# Altium schematic sheet weight V4.0.SchDoc
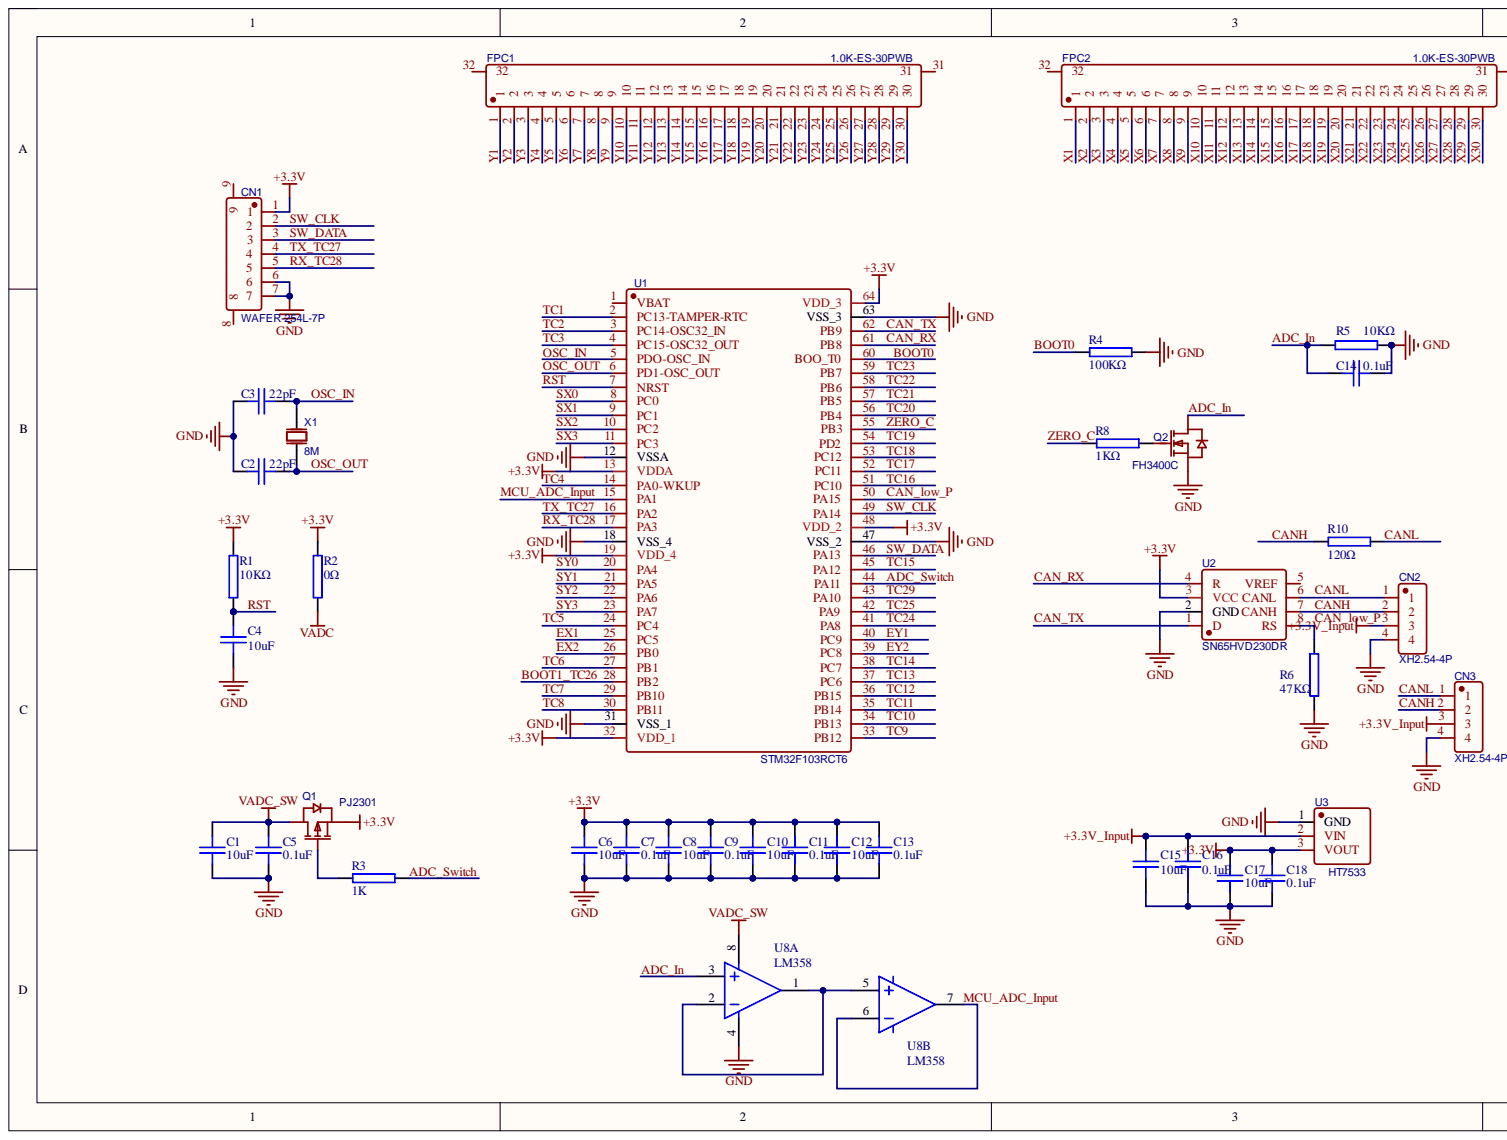
[diagram p0: weight V4.0.SchDoc, left]
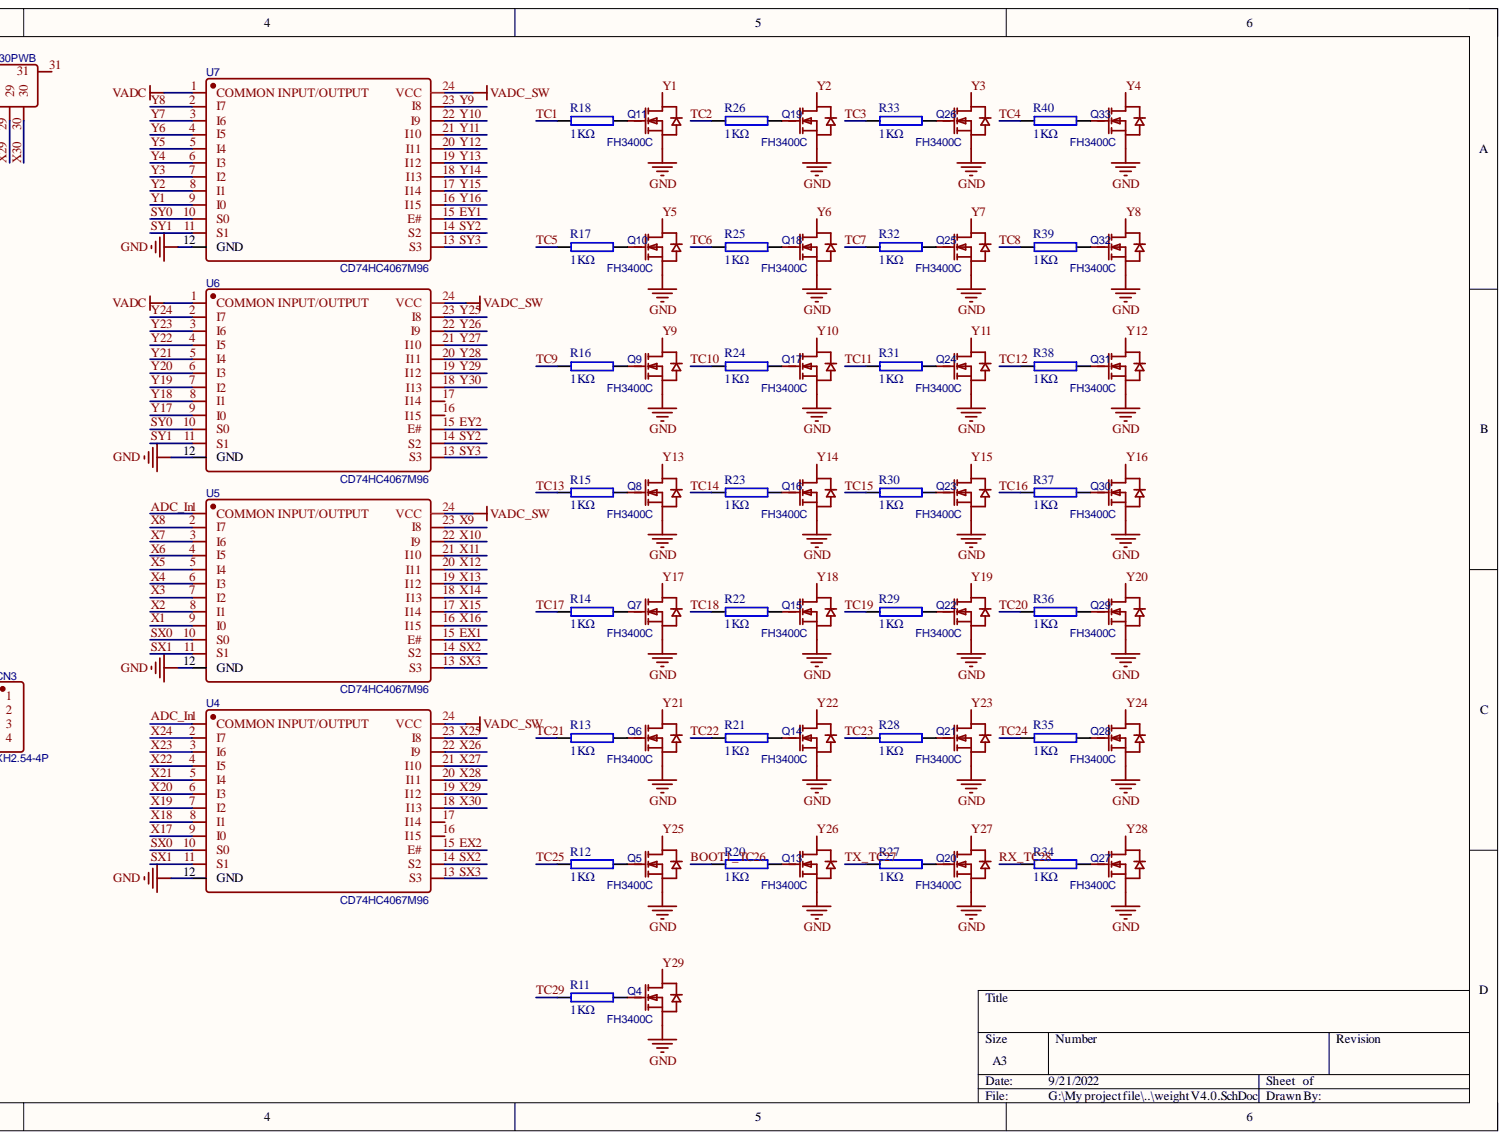
[diagram p1: weight V4.0.SchDoc, right]

## Parts
C1  10uF  [C0805_L]
C2  22pF  [C0603_L]
C3  22pF  [C0603_L]
C4  10uF  [C0805_L]
C5  0.1uF  [C0603_L]
C6  10uF  [C0805_L]
C7  0.1uF  [C0603_L]
C8  10uF  [C0805_L]
C9  0.1uF  [C0603_L]
C10  10uF  [C0805_L]
C11  0.1uF  [C0603_L]
C12  10uF  [C0805_L]
C13  0.1uF  [C0603_L]
C14  0.1uF  [C0603_L]
C15  10uF  [C0805_L]
C16  0.1uF  [C0603_L]
C17  10uF  [C0805_L]
C18  0.1uF  [C0603_L]
CN1  WAFER-254L-7P  [HDR2.54-LI-7P]
CN2  XH2.54-4P  [CONN-SMD_HY2.0-4P]
CN3  XH2.54-4P  [CONN-SMD_HY2.0-4P]
FPC1  1.0K-ES-30PWB  [SH1.0 2*15P WT]
FPC2  1.0K-ES-30PWB  [SH1.0 2*15P WT]
Q1  PJ2301  [SOT23]
Q2  FH3400C  [SOT-23-3]
Q4  FH3400C  [SOT-23-3]
Q5  FH3400C  [SOT-23-3]
Q6  FH3400C  [SOT-23-3]
Q7  FH3400C  [SOT-23-3]
Q8  FH3400C  [SOT-23-3]
Q9  FH3400C  [SOT-23-3]
Q10  FH3400C  [SOT-23-3]
Q11  FH3400C  [SOT-23-3]
Q13  FH3400C  [SOT-23-3]
Q14  FH3400C  [SOT-23-3]
Q15  FH3400C  [SOT-23-3]
Q16  FH3400C  [SOT-23-3]
Q17  FH3400C  [SOT-23-3]
Q18  FH3400C  [SOT-23-3]
Q19  FH3400C  [SOT-23-3]
Q20  FH3400C  [SOT-23-3]
Q21  FH3400C  [SOT-23-3]
Q22  FH3400C  [SOT-23-3]
Q23  FH3400C  [SOT-23-3]
Q24  FH3400C  [SOT-23-3]
Q25  FH3400C  [SOT-23-3]
Q26  FH3400C  [SOT-23-3]
Q27  FH3400C  [SOT-23-3]
Q28  FH3400C  [SOT-23-3]
Q29  FH3400C  [SOT-23-3]
Q30  FH3400C  [SOT-23-3]
Q31  FH3400C  [SOT-23-3]
Q32  FH3400C  [SOT-23-3]
Q33  FH3400C  [SOT-23-3]
R1  10KΩ  [R0603_L]
R2  0Ω  [R0603_L]
R3  1K  [R0603_L]
R4  100KΩ  [R0603_L]
R5  10KΩ  [R0603_L]
R6  47KΩ  [R0603_L]
R8  1KΩ  [R0603_L]
R10  120Ω  [R0603_L]
R11  1KΩ  [R0603_L]
R12  1KΩ  [R0603_L]
R13  1KΩ  [R0603_L]
R14  1KΩ  [R0603_L]
R15  1KΩ  [R0603_L]
R16  1KΩ  [R0603_L]
R17  1KΩ  [R0603_L]
R18  1KΩ  [R0603_L]
R20  1KΩ  [R0603_L]
R21  1KΩ  [R0603_L]
R22  1KΩ  [R0603_L]
R23  1KΩ  [R0603_L]
R24  1KΩ  [R0603_L]
R25  1KΩ  [R0603_L]
R26  1KΩ  [R0603_L]
R27  1KΩ  [R0603_L]
R28  1KΩ  [R0603_L]
R29  1KΩ  [R0603_L]
R30  1KΩ  [R0603_L]
R31  1KΩ  [R0603_L]
R32  1KΩ  [R0603_L]
R33  1KΩ  [R0603_L]
R34  1KΩ  [R0603_L]
R35  1KΩ  [R0603_L]
R36  1KΩ  [R0603_L]
R37  1KΩ  [R0603_L]
R38  1KΩ  [R0603_L]
R39  1KΩ  [R0603_L]
R40  1KΩ  [R0603_L]
U1  STM32F103RCT6  [LQFP64_L]
U2  SN65HVD230DR  [SOIC-8]
U3  HT7533  [SOT-89]
U4  CD74HC4067M96  [SSOP24]
U5  CD74HC4067M96  [SSOP24]
U6  CD74HC4067M96  [SSOP24]
U7  CD74HC4067M96  [SSOP24]
U8  LM358  [SOIC-8L]  (parts A,B)
X1  8M  [OSC-5032-2P]

## Nets
+3.3V: C6.2 C7.2 C8.2 C9.2 C10.2 C11.2 C12.2 C13.2 C17.2 C18.2 CN1.1 Q1.2 R1.1 R2.1 U1.13(VDDA) U1.19(VDD_4) U1.32(VDD_1) U1.48(VDD_2) U1.64(VDD_3) U2.3(VCC) U3.3
+3.3V_Input: C15.2 C16.2 CN2.3 CN3.3 U3.2
GND: C1.2 C2.2 C3.2 C4.1 C5.2 C6.1 C7.1 C8.1 C9.1 C10.1 C11.1 C12.1 C13.1 C14.1 C15.1 C16.1 C17.1 C18.1 CN1.6 CN1.7 CN2.4 CN3.4 Q2.2 Q4.2 Q5.2 Q6.2 Q7.2 Q8.2 Q9.2 Q10.2 Q11.2 Q13.2 Q14.2 Q15.2 Q16.2 Q17.2 Q18.2 Q19.2 Q20.2 Q21.2 Q22.2 Q23.2 Q24.2 Q25.2 Q26.2 Q27.2 Q28.2 Q29.2 Q30.2 Q31.2 Q32.2 Q33.2 R4.1 R5.2 R6.2 U1.12(VSSA) U1.18(VSS_4) U1.31(VSS_1) U1.47(VSS_2) U1.63(VSS_3) U2.2(GND) U3.1 U4.12(GND) U5.12(GND) U6.12(GND) U7.12(GND) U8.4
VADC: R2.2 U6.1 U7.1
VADC_SW: C1.1 C5.1 Q1.3 U4.24(VCC) U5.24(VCC) U6.24(VCC) U7.24(VCC) U8.8
ADC_In: C14.2 Q2.3 R5.1 U4.1 U5.1 U8.3
ADC_Switch: R3.2 U1.44(PA11)
BOOT0: R4.2 U1.60(BOO_T0)
BOOT1_TC26: R20.1 U1.28(PB2)
CAN_low_P: R6.1 U1.50(PA15) U2.8(RS)
CAN_RX: U1.61(PB8) U2.4(R)
CAN_TX: U1.62(PB9) U2.1(D)
CANH: CN2.2 CN3.2 R10.2 U2.7(CANH)
CANL: CN2.1 CN3.1 R10.1 U2.6(CANL)
EX1: U1.25(PC5) U5.15(E#)
EX2: U1.26(PB0) U4.15(E#)
EY1: U1.40(PC9) U7.15(E#)
EY2: U1.39(PC8) U6.15(E#)
MCU_ADC_Input: U1.15(PA1) U8.6 U8.7
OSC_IN: C3.1 U1.5(PDO-OSC_IN) X1.2
OSC_OUT: C2.1 U1.6(PD1-OSC_OUT) X1.1
RST: C4.2 R1.2 U1.7(NRST)
RX_TC28: CN1.5 R34.1 U1.17(PA3)
SW_CLK: CN1.2 U1.49(PA14)
SW_DATA: CN1.3 U1.46(PA13)
SX0: U1.8(PC0) U4.10(S0) U5.10(S0)
SX1: U1.9(PC1) U4.11(S1) U5.11(S1)
SX2: U1.10(PC2) U4.14(S2) U5.14(S2)
SX3: U1.11(PC3) U4.13(S3) U5.13(S3)
SY0: U1.20(PA4) U6.10(S0) U7.10(S0)
SY1: U1.21(PA5) U6.11(S1) U7.11(S1)
SY2: U1.22(PA6) U6.14(S2) U7.14(S2)
SY3: U1.23(PA7) U6.13(S3) U7.13(S3)
TC1: R18.1 U1.2(PC13-TAMPER-RTC)
TC2: R26.1 U1.3(PC14-OSC32_IN)
TC3: R33.1 U1.4(PC15-OSC32_OUT)
TC4: R40.1 U1.14(PA0-WKUP)
TC5: R17.1 U1.24(PC4)
TC6: R25.1 U1.27(PB1)
TC7: R32.1 U1.29(PB10)
TC8: R39.1 U1.30(PB11)
TC9: R16.1 U1.33(PB12)
TC10: R24.1 U1.34(PB13)
TC11: R31.1 U1.35(PB14)
TC12: R38.1 U1.36(PB15)
TC13: R15.1 U1.37(PC6)
TC14: R23.1 U1.38(PC7)
TC15: R30.1 U1.45(PA12)
TC16: R37.1 U1.51(PC10)
TC17: R14.1 U1.52(PC11)
TC18: R22.1 U1.53(PC12)
TC19: R29.1 U1.54(PD2)
TC20: R36.1 U1.56(PB4)
TC21: R13.1 U1.57(PB5)
TC22: R21.1 U1.58(PB6)
TC23: R28.1 U1.59(PB7)
TC24: R35.1 U1.41(PA8)
TC25: R12.1 U1.42(PA9)
TC29: R11.1 U1.43(PA10)
TX_TC27: CN1.4 R27.1 U1.16(PA2)
X1: FPC2.1 U5.9(I0)
X2: FPC2.2 U5.8(I1)
X3: FPC2.3 U5.7(I2)
X4: FPC2.4 U5.6(I3)
X5: FPC2.5 U5.5(I4)
X6: FPC2.6 U5.4(I5)
X7: FPC2.7 U5.3(I6)
X8: FPC2.8 U5.2(I7)
X9: FPC2.9 U5.23(I8)
X10: FPC2.10 U5.22(I9)
X11: FPC2.11 U5.21(I10)
X12: FPC2.12 U5.20(I11)
X13: FPC2.13 U5.19(I12)
X14: FPC2.14 U5.18(I13)
X15: FPC2.15 U5.17(I14)
X16: FPC2.16 U5.16(I15)
X17: FPC2.17 U4.9(I0)
X18: FPC2.18 U4.8(I1)
X19: FPC2.19 U4.7(I2)
X20: FPC2.20 U4.6(I3)
X21: FPC2.21 U4.5(I4)
X22: FPC2.22 U4.4(I5)
X23: FPC2.23 U4.3(I6)
X24: FPC2.24 U4.2(I7)
X25: FPC2.25 U4.23(I8)
X26: FPC2.26 U4.22(I9)
X27: FPC2.27 U4.21(I10)
X28: FPC2.28 U4.20(I11)
X29: FPC2.29 U4.19(I12)
X30: FPC2.30 U4.18(I13)
Y1: FPC1.1 Q11.3 U7.9(I0)
Y2: FPC1.2 Q19.3 U7.8(I1)
Y3: FPC1.3 Q26.3 U7.7(I2)
Y4: FPC1.4 Q33.3 U7.6(I3)
Y5: FPC1.5 Q10.3 U7.5(I4)
Y6: FPC1.6 Q18.3 U7.4(I5)
Y7: FPC1.7 Q25.3 U7.3(I6)
Y8: FPC1.8 Q32.3 U7.2(I7)
Y9: FPC1.9 Q9.3 U7.23(I8)
Y10: FPC1.10 Q17.3 U7.22(I9)
Y11: FPC1.11 Q24.3 U7.21(I10)
Y12: FPC1.12 Q31.3 U7.20(I11)
Y13: FPC1.13 Q8.3 U7.19(I12)
Y14: FPC1.14 Q16.3 U7.18(I13)
Y15: FPC1.15 Q23.3 U7.17(I14)
Y16: FPC1.16 Q30.3 U7.16(I15)
Y17: FPC1.17 Q7.3 U6.9(I0)
Y18: FPC1.18 Q15.3 U6.8(I1)
Y19: FPC1.19 Q22.3 U6.7(I2)
Y20: FPC1.20 Q29.3 U6.6(I3)
Y21: FPC1.21 Q6.3 U6.5(I4)
Y22: FPC1.22 Q14.3 U6.4(I5)
Y23: FPC1.23 Q21.3 U6.3(I6)
Y24: FPC1.24 Q28.3 U6.2(I7)
Y25: FPC1.25 Q5.3 U6.23(I8)
Y26: FPC1.26 Q13.3 U6.22(I9)
Y27: FPC1.27 Q20.3 U6.21(I10)
Y28: FPC1.28 Q27.3 U6.20(I11)
Y29: FPC1.29 Q4.3 U6.19(I12)
Y30: FPC1.30 U6.18(I13)
ZERO_C: R8.1 U1.55(PB3)
NetQ1_1: Q1.1 R3.1
NetQ2_1: Q2.1 R8.2
NetQ4_1: Q4.1 R11.2
NetQ5_1: Q5.1 R12.2
NetQ6_1: Q6.1 R13.2
NetQ7_1: Q7.1 R14.2
NetQ8_1: Q8.1 R15.2
NetQ9_1: Q9.1 R16.2
NetQ10_1: Q10.1 R17.2
NetQ11_1: Q11.1 R18.2
NetQ13_1: Q13.1 R20.2
NetQ14_1: Q14.1 R21.2
NetQ15_1: Q15.1 R22.2
NetQ16_1: Q16.1 R23.2
NetQ17_1: Q17.1 R24.2
NetQ18_1: Q18.1 R25.2
NetQ19_1: Q19.1 R26.2
NetQ20_1: Q20.1 R27.2
NetQ21_1: Q21.1 R28.2
NetQ22_1: Q22.1 R29.2
NetQ23_1: Q23.1 R30.2
NetQ24_1: Q24.1 R31.2
NetQ25_1: Q25.1 R32.2
NetQ26_1: Q26.1 R33.2
NetQ27_1: Q27.1 R34.2
NetQ28_1: Q28.1 R35.2
NetQ29_1: Q29.1 R36.2
NetQ30_1: Q30.1 R37.2
NetQ31_1: Q31.1 R38.2
NetQ32_1: Q32.1 R39.2
NetQ33_1: Q33.1 R40.2
NetU8_1: U8.1 U8.2 U8.5

Not connected: CN1 8 9; FPC1 31 32; FPC2 31 32; U1 1(VBAT); U2 5(VREF); U4 16(I15) 17(I14); U6 16(I15) 17(I14)

## Library data of the parts
C1-C18 = 02.01.00357: Database Table Name: VErpDataInfo; Component Kind: Standard; Library Name: ErpMaterialData-U9.DbLib
CN1 = WAFER-254L-7P: BOM_Manufacturer: XUNPU(讯普); Contributor: LCSC; BOM_JLCPCB Part Class: Extended Part; BOM_Manufacturer Part: WAFER-254L-7P; BOM_Supplier: LCSC; BOM_Supplier Part: C2856689; Component Kind: Standard; spicePre: C; spiceSymbolName: WAFER-254L-7P
CN2 CN3 = HX25003-4A: BOM_Manufacturer: HX(Zhejiang Yueqing Hongxing Elec); Contributor: LCSC; BOM_JLCPCB Part Class: Extended Part; BOM_Manufacturer Part: HX25003-4A; BOM_Supplier: LCSC; BOM_Supplier Part: C442301; Component Kind: Standard; Library Name: PCB_Project.SCHLIB; spicePre: C; spiceSymbolName: HX25003-4A
FPC1 FPC2 = 1.0K-ES-30PWB: BOM_Manufacturer: HDGC(华德共创); Contributor: LCSC; BOM_JLCPCB Part Class: Extended Part; BOM_Manufacturer Part: 1.0K-ES-30PWB; BOM_Supplier: LCSC; BOM_Supplier Part: C2915303; Component Kind: Standard; spicePre: F; spiceSymbolName: 1.0K-ES-30PWB
Q1 = PJ2301: BOM_Manufacturer: PANJIT International; Contributor: LCSC; BOM_JLCPCB Part Class: Extended Part; BOM_Manufacturer Part: PJ2301; BOM_Supplier: LCSC; BOM_Supplier Part: C304448; Component Kind: Standard; spicePre: Q; spiceSymbolName: PJ2301
Q2 Q4-Q11 Q13-Q33 = FH3400C: BOM_Manufacturer: 鑫飞宏; Contributor: LCSC; BOM_JLCPCB Part Class: Extended Part; BOM_Manufacturer Part: FH3400C; BOM_Supplier: LCSC; BOM_Supplier Part: C2940037; Component Kind: Standard; spicePre: Q; spiceSymbolName: FH3400C
R1-R6 R8 R10-R18 R20-R40 = 01.01.00008: Database Table Name: VErpDataInfo; Component Kind: Standard; Library Name: ErpMaterialData-U9.DbLib
U1 = STM32F103RCT6: BOM_Manufacturer: STMicroelectronics; BOM_JLCPCB Part Class: Extended Part; BOM_Manufacturer Part: STM32F103RCT6; BOM_Supplier: LCSC; BOM_Supplier Part: C8323; Component Kind: Standard; spicePre: U; spiceSymbolName: STM32F103RCT6
U2 = SN65HVD230DR: BOM_Manufacturer: TI; BOM_JLCPCB Part Class: Basic Part; BOM_Manufacturer Part: SN65HVD230DR; BOM_Supplier: LCSC; BOM_Supplier Part: C12084; Component Kind: Standard; spicePre: U; spiceSymbolName: SN65HVD230DR
U3 = HT7533: BOM_Manufacturer: KEXIN; Contributor: LCSC; BOM_JLCPCB Part Class: Extended Part; BOM_Manufacturer Part: HT7533; BOM_Supplier: LCSC; BOM_Supplier Part: C499633; Component Kind: Standard; spicePre: U; spiceSymbolName: HT7533
U4-U7 = CD74HC4067M96: BOM_Manufacturer: Texas Instruments; Contributor: LCSC; BOM_JLCPCB Part Class: Extended Part; BOM_Manufacturer Part: CD74HC4067M96; BOM_Supplier: LCSC; BOM_Supplier Part: C496123; Component Kind: Standard; spicePre: U; spiceSymbolName: CD74HC4067M96
U8 = JRC-NJM5532M(DMP-8): 芯片-双路运放; Component Kind: Standard; Library Name: IC_SCH.SchLib
X1 = X50328MSD2GC: BOM_Manufacturer: YXC; Value: 8MHZ; BOM_JLCPCB Part Class: Extended Part; BOM_Manufacturer Part: X50328MSD2GC; BOM_Supplier: LCSC; BOM_Supplier Part: C18560; Component Kind: Standard; spicePre: X; spiceSymbolName: X50328MSD2GC
